# Altium schematic sheet ELRS_RX_E32.SchDoc
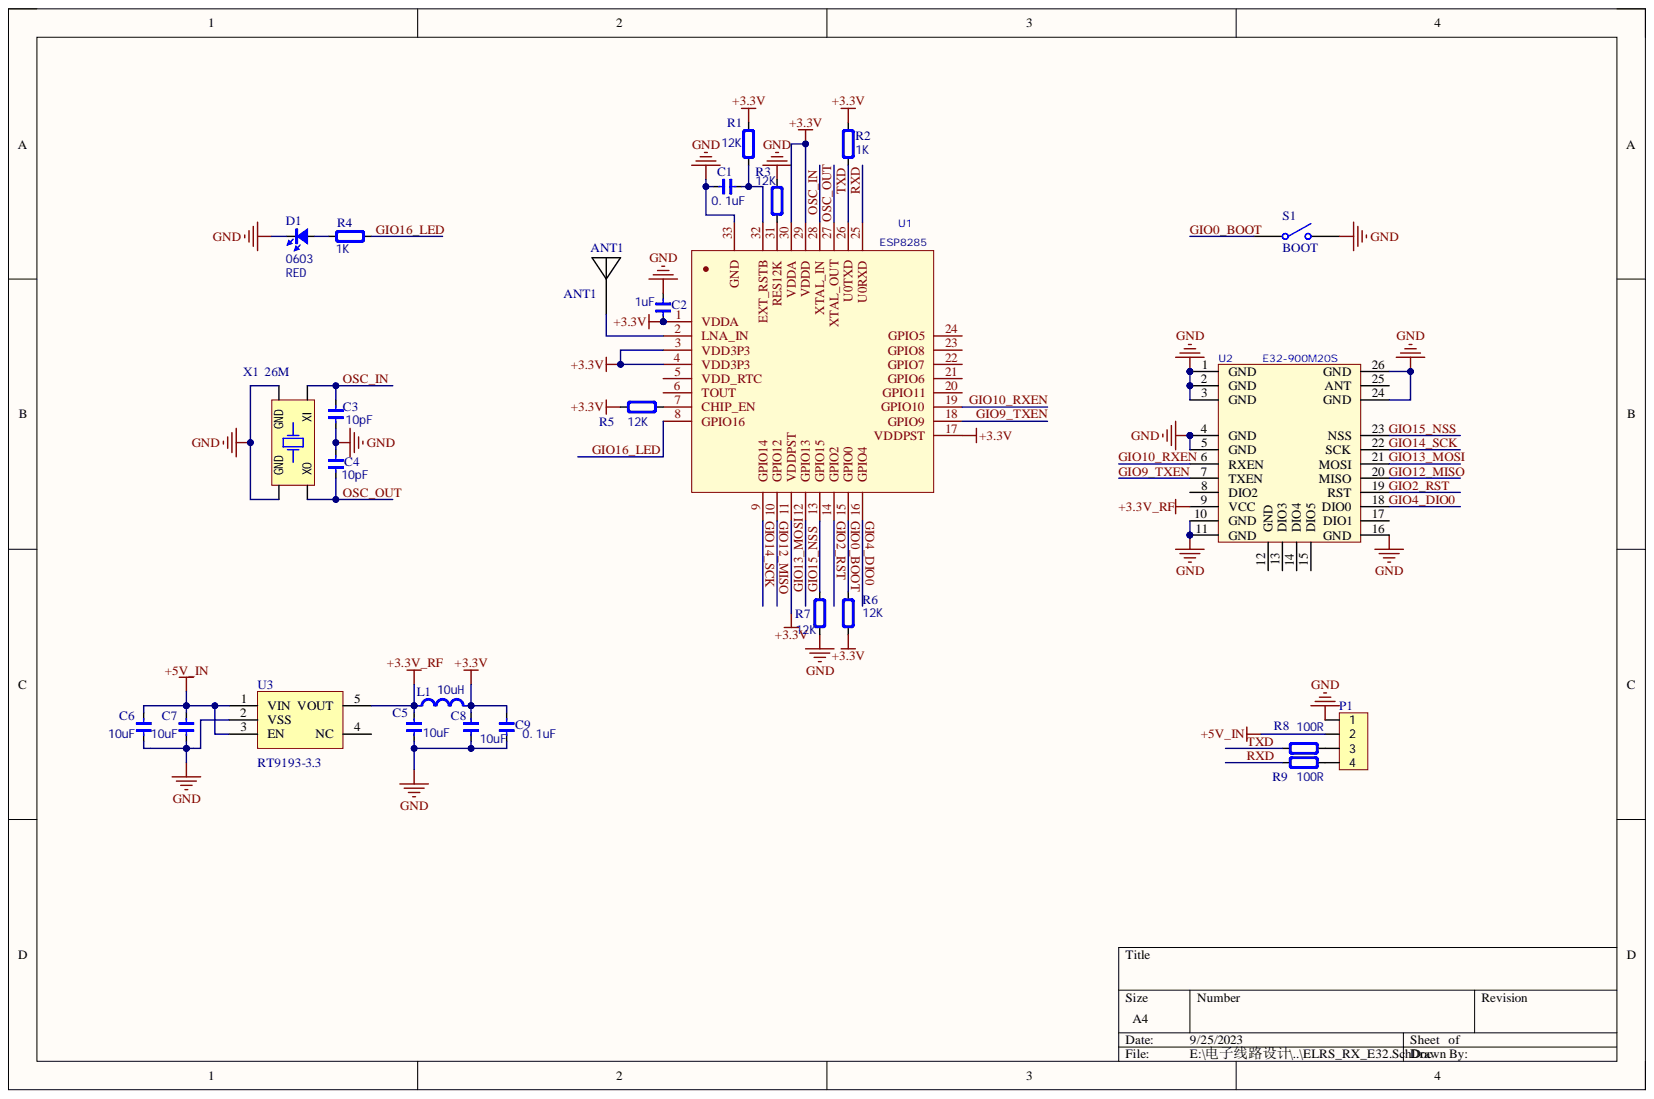
[diagram p0: ELRS_RX_E32.SchDoc]

## Parts
ANT1  ANT1  [2.4_ANT]
C1  0.1uF  [CAP_0402 - L]
C2  1uF  [CAP_0402 - L]
C3  10pF  [CAP_0402 - L]
C4  10pF  [CAP_0402 - L]
C5  10uF  [CAP_0603]
C6  10uF  [CAP_0603]
C7  10uF  [CAP_0603]
C8  10uF  [CAP_0603]
C9  0.1uF  [CAP_0402 - L]
D1  D0603  value RED  Type: 0603  [LED_0603_RED]
L1  10uH  [IND_0603]
P1  HDR1x4  [HDR1x4_2.54_180]  (value not drawn)
R1  12K  [RES_0402 - L]
R2  1K  [RES_0402 - L]
R3  12K  [RES_0402 - L]
R4  1K  [RES_0402 - L]
R5  12K  [RES_0402 - L]
R6  12K  [RES_0402 - L]
R7  12K  [RES_0402 - L]
R8  100R  [RES_0402 - L]
R9  100R  [RES_0402 - L]
S1  BOOT  [SPARKFUN-JUMPERS_SMT-JUMPER_2_NC_TRACE_SILK]
U1  ESP8285  [QFN_P0.5_32]
U2  E32-900M20S  [E32-900M20S - L]
U3  RT9193-3.3  [SOT23-5]
X1  26M  [XT_2520_4]

## Nets
+3.3V: C2.1 C8.2 C9.2 L1.2 R1.1 R2.1 R5.2 R6.2 U1.1(VDDA) U1.3(VDD3P3) U1.4(VDD3P3) U1.11(VDDPST) U1.17(VDDPST) U1.29(VDDD) U1.30(VDDA)
+3.3V_RF: C5.2 L1.1 U2.9(VCC) U3.5(VOUT)
+5V_IN: C6.2 C7.2 P1.2 U3.1(VIN) U3.3(EN)
GND: C1.2 C2.2 C3.2 C4.1 C5.1 C6.1 C7.1 C8.1 C9.1 D1.2 P1.1 R3.1 R7.2 S1.2 U1.33(GND) U2.1(GND) U2.2(GND) U2.3(GND) U2.4(GND) U2.5(GND) U2.10(GND) U2.11(GND) U2.16(GND) U2.24(GND) U2.26(GND) U3.2(VSS) X1.2(GND) X1.4(GND)
GIO0_BOOT: R6.1 S1.1 U1.15(GPIO0)
GIO2_RST: U1.14(GPIO2) U2.19(RST)
GIO4_DIO0: U1.16(GPIO4) U2.18(DIO0)
GIO9_TXEN: U1.18(GPIO9) U2.7(TXEN)
GIO10_RXEN: U1.19(GPIO10) U2.6(RXEN)
GIO12_MISO: U1.10(GPIO12) U2.20(MISO)
GIO13_MOSI: U1.12(GPIO13) U2.21(MOSI)
GIO14_SCK: U1.9(GPIO14) U2.22(SCK)
GIO15_NSS: R7.1 U1.13(GPIO15) U2.23(NSS)
GIO16_LED: R4.1 U1.8(GPIO16)
OSC_IN: C3.1 U1.28(XTAL_IN) X1.1(XI)
OSC_OUT: C4.2 U1.27(XTAL_OUT) X1.3(XO)
RXD: R9.1 U1.25(U0RXD)
TXD: R2.2 R8.1 U1.26(U0TXD)
NetANT1_1: ANT1.1 U1.2(LNA_IN)
NetC1_1: C1.1 R1.2 U1.32(EXT_RSTB)
NetD1_1: D1.1 R4.2
NetP1_3: P1.3 R8.2
NetP1_4: P1.4 R9.2
NetR3_2: R3.2 U1.31(RES12K)
NetR5_1: R5.1 U1.7(CHIP_EN)

Not connected: U1 5(VDD_RTC) 6(TOUT) 20(GPIO11) 21(GPIO6) 22(GPIO7) 23(GPIO8) 24(GPIO5); U2 8(DIO2) 12(GND) 13(DIO3) 14(DIO4) 15(DIO5) 17(DIO1) 25(ANT); U3 4(NC)

## Library data of the parts
ANT1 = ANT1: PCB板载天线; 版权归属: 源创客; BOM名称: PCB板载天线; Component Kind: Standard; Library Name: 14.1 - 天线.SchLib; 学习资源: www.ooocke.com
C1 C3-C7 C9 = Cap_0402: Capacitor; Note: ±10%; Specification: X7R, ±10%, 16V; Type: 0402; Component Kind: Standard; Library Name: SCH_Passive.SchLib
  C1 C9: Value: 0.1uF
  C3 C4: Value: 10pF
  C5-C7: Value: 10uF
C2 = Cap_0402: Capacitor; Note: ±10%; Specification: X7R, ±10%, 16V; Type: 0402; Value: 0.1uF; Component Kind: Standard; Library Name: SCH_Passive.SchLib
C8 = Cap_0402: Capacitor; Note: ±10%; Specification: X7R, ±10%, 16V; Type: 0402; Value: 4.7uF; Component Kind: Standard; Library Name: SCH_Passive.SchLib
D1 = LED_0603: LED; Component Kind: Standard; Library Name: SCH_Active.SchLib
L1 = Ind_0603: Inductor; Note: ±5%; Specification: High frequency chip inductor ±5%; Type: 0603; Component Kind: Standard; Library Name: SCH_Passive.SchLib; Value: 10uH
P1 = HDR1x4_2.54: Header; Value: 2.54; Component Kind: Standard; Library Name: SCH_ConnectorHDR.SchLib; Type: DIP 1x4
R1-R7 = Res_0402: Resistor; Note: ±1%; Component Kind: Standard; Library Name: SCH_Passive.SchLib; Specification: ±1%; Type: 0402
  R1 R3 R5-R7: Value: 12K
  R2 R4: Value: 1K
R8 R9 = Res_0402: Resistor; Note: ±1%; Value: 12K; Component Kind: Standard; Library Name: SCH_Passive.SchLib; Specification: ±1%; Type: 0402
S1 = SwitchSPST: Switch; Component Kind: Standard; Library Name: SCH_Switch.SchLib; Type: SPST 2P
U3 = SPX3819M5: Component Kind: Standard; Library Name: SCH_Power.SchLib
X1 = XTAL_2520_4P: Crystal Oscillator; Specification: ±10ppm; Value: XTAL2520; Component Kind: Standard; Library Name: SCH_Oscillator.SchLib; Type: XTAL2520_4P
U1 = ESP8285: Component Kind: Standard; Library Name: Schlib.SchLib
U2 = E32-900M20S: Component Kind: Standard; Library Name: Schlib.SchLib
